# Altium schematic sheet [06] CONNECTIVITY.SchDoc("[06] CONNECTIVITY")
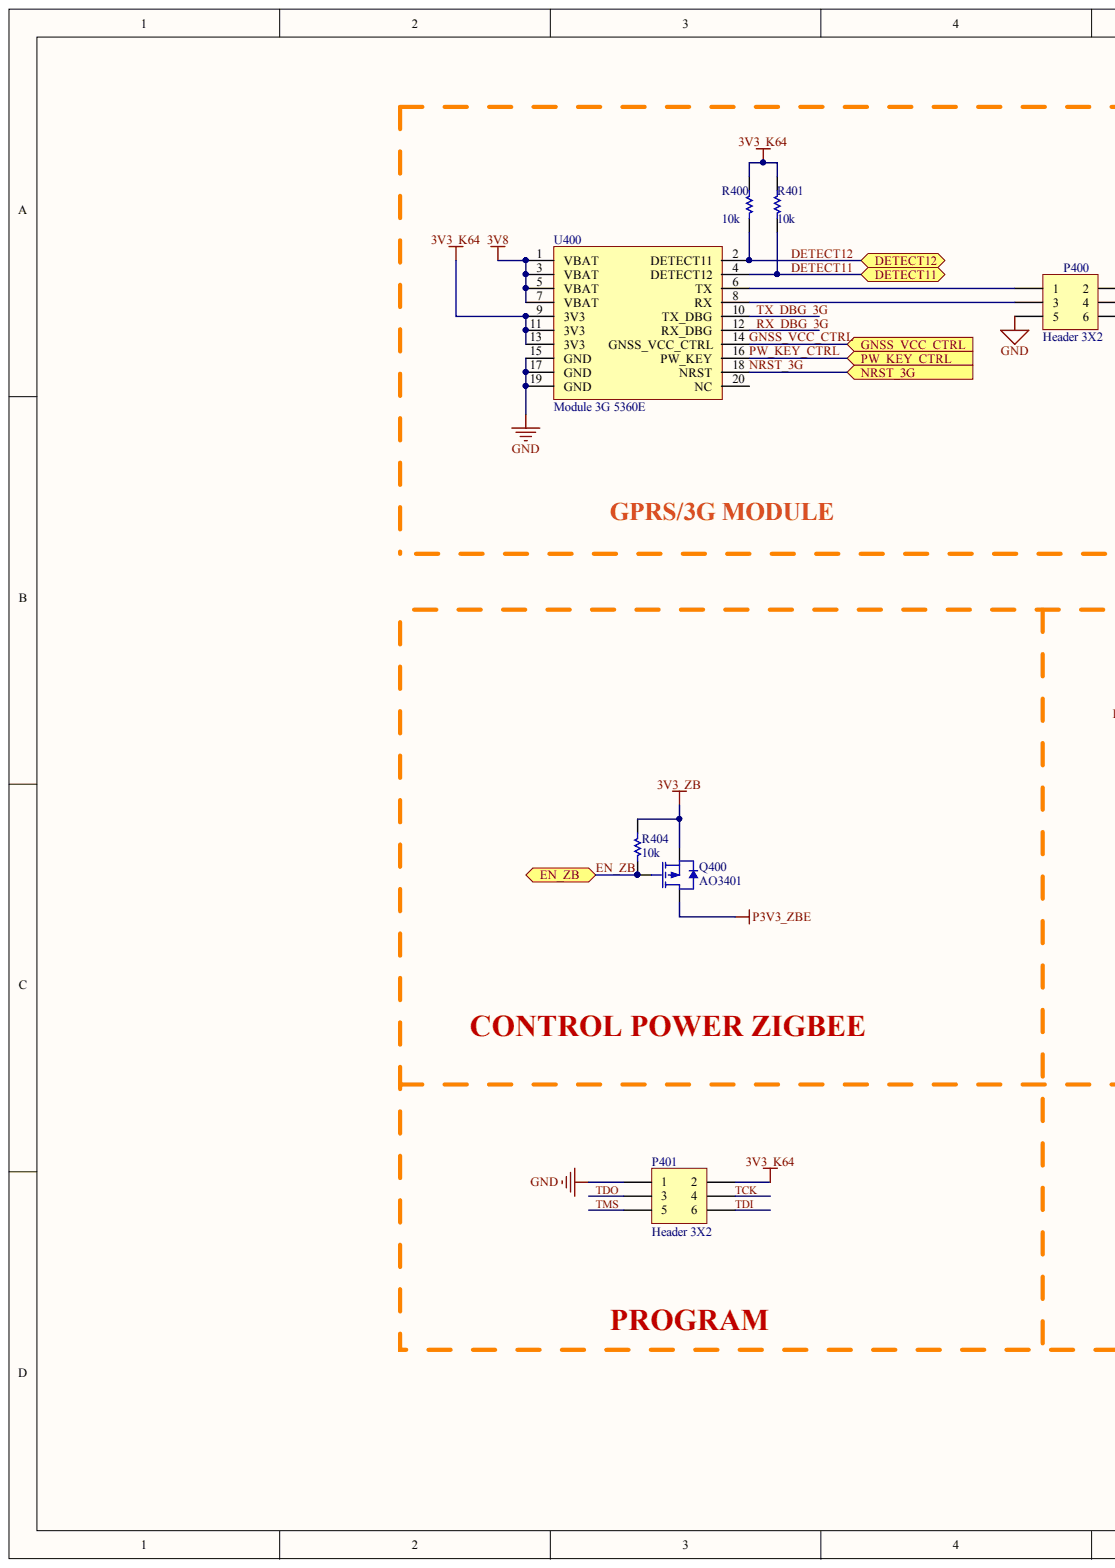
[diagram p0: [06] CONNECTIVITY.SchDoc, left]
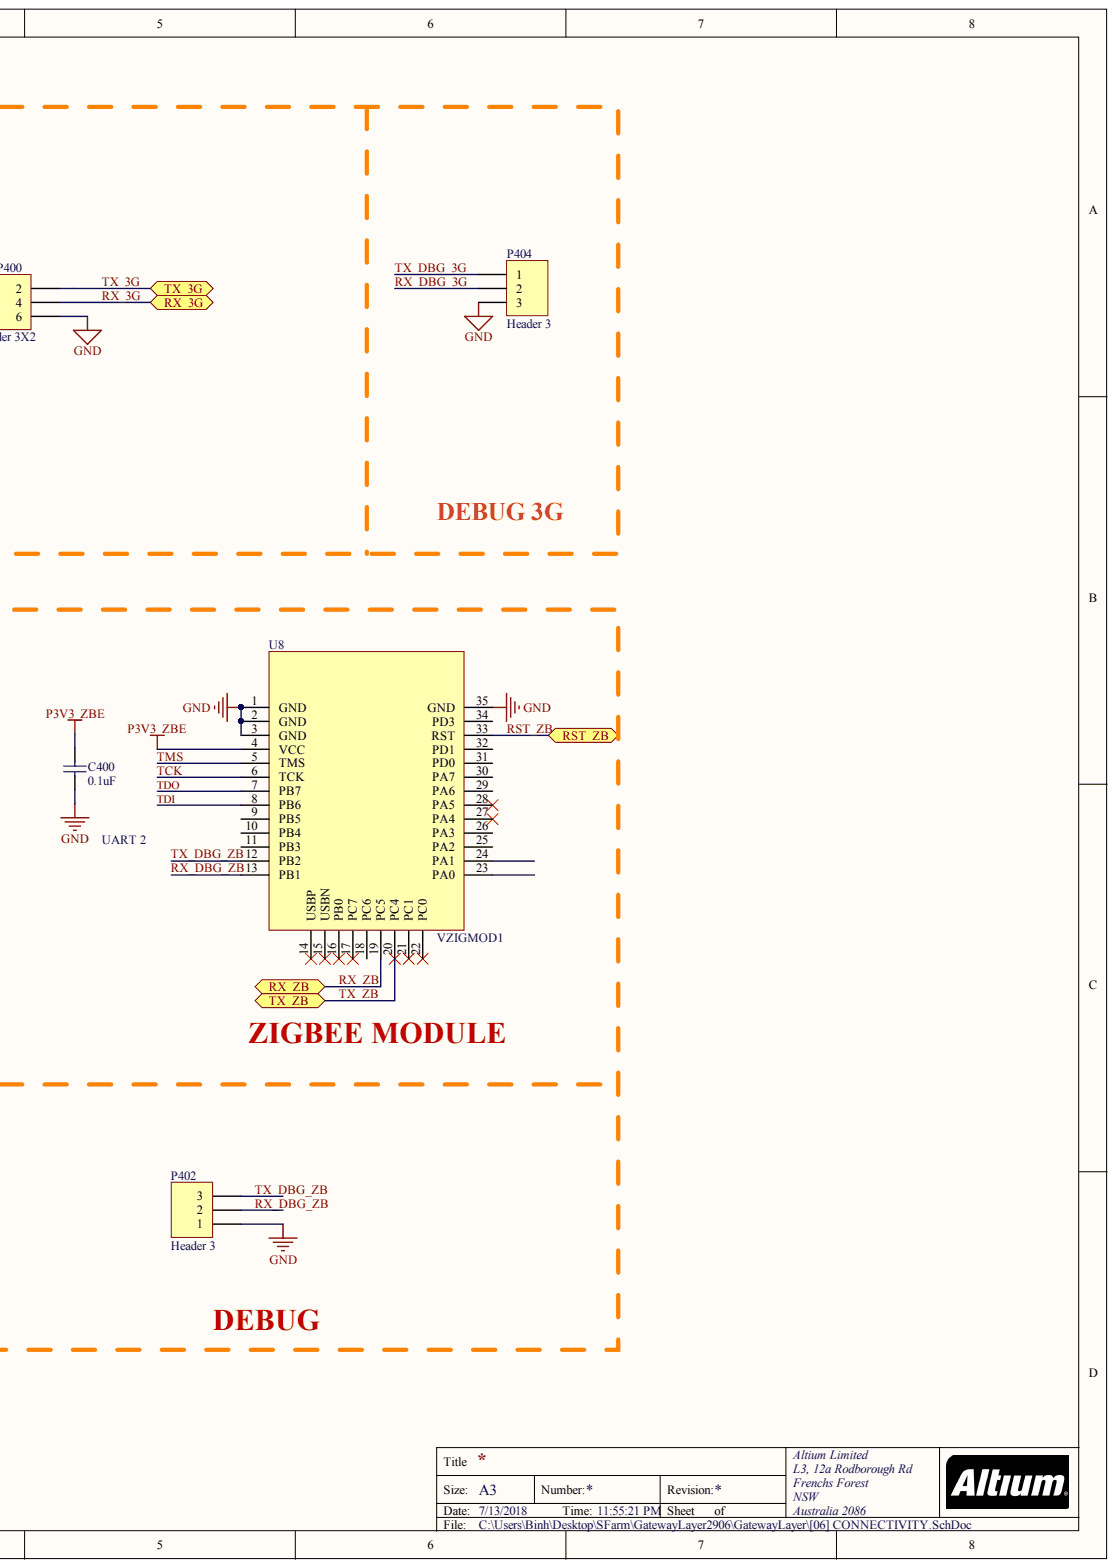
[diagram p1: [06] CONNECTIVITY.SchDoc, right]

## Parts
C400  0.1uF  [0402C]
P400  Header 3X2  [HDR2X3]
P401  Header 3X2  [HDR2X3]
P402  Header 3  [HDR1X3]
P404  Header 3  [HDR1X3]
Q400  AO3401  [SOT23-3N]
R400  10k  [0603R]
R401  10k  [0603R]
R404  10k  [0603R]
U8  VZIGMOD1  [VZIGMOD2]
U400  Module 3G 5360E  [Module 3G]

## Ports
DETECT11, DETECT12, EN_ZB, GNSS_VCC_CTRL, NRST_3G, PW_KEY_CTRL, RST_ZB, RX_3G, RX_ZB, TX_3G, TX_ZB

## Nets
3V3_K64: P401.2 R400.2 R401.2 U400.9(3V3) U400.11(3V3) U400.13(3V3)
3V3_ZB: Q400.2 R404.2
3V8: U400.1(VBAT) U400.3(VBAT) U400.5(VBAT) U400.7(VBAT)
GND: C400.1 P400.5 P400.6 P401.1 P402.1 P404.3 U8.1(GND) U8.2(GND) U8.3(GND) U8.35(GND) U400.15(GND) U400.17(GND) U400.19(GND)
P3V3_ZBE: C400.2 Q400.3 U8.4(VCC)
DETECT11: R401.1 U400.4(DETECT12) ; port DETECT11
DETECT12: R400.1 U400.2(DETECT11) ; port DETECT12
EN_ZB: Q400.1 R404.1 ; port EN_ZB
GNSS_VCC_CTRL: U400.14(GNSS_VCC_CTRL) ; port GNSS_VCC_CTRL
NRST_3G: U400.18(NRST) ; port NRST_3G
PW_KEY_CTRL: U400.16(PW_KEY) ; port PW_KEY_CTRL
RST_ZB: U8.33(RST) ; port RST_ZB
RX_3G: P400.4 ; port RX_3G
RX_DBG_3G: P404.2 U400.12(RX_DBG)
RX_DBG_ZB: P402.2 U8.13(PB1)
RX_ZB: U8.19(PC5) ; port RX_ZB
TCK: P401.4 U8.6(TCK)
TDI: P401.6 U8.8(PB6)
TDO: P401.3 U8.7(PB7)
TMS: P401.5 U8.5(TMS)
TX_3G: P400.2 ; port TX_3G
TX_DBG_3G: P404.1 U400.10(TX_DBG)
TX_DBG_ZB: P402.3 U8.12(PB2)
TX_ZB: U8.20(PC4) ; port TX_ZB
NetP400_1: P400.1 U400.6(TX)
NetP400_3: P400.3 U400.8(RX)

Not connected: U8 9(PB5) 10(PB4) 11(PB3) 14(USBP) 15(USBN) 16(PB0) 17(PC7) 18(PC6) 21(PC1) 22(PC0) 23(PA0) 24(PA1) 25(PA2) 26(PA3) 27(PA4) 28(PA5) 29(PA6) 30(PA7) 31(PD0) 32(PD1) 34(PD3); U400 20(NC)

## Library data of the parts
C400 = CAP0.1u0402: CAP CER 0.1UF 16V X7R 0402; Manufacturer: Samsung Electro-Mechanics; Manufacturer Part Number: CL05B104KO5NNNC; Module: Connectivity; Notification: Current market demand for these product types have resulted in fluctuating and extending lead times. Lead times may differ.; Tolerance: ±10%; Applications: General Purpose; Category: Capacitors; Component Kind: Standard; ComponentLink1Description: http://www.samsungsem.com/kr/support/product-search/mlcc/CL05B104KO5NNNC.jsp; ComponentLink1URL: http://www.samsungsem.com/kr/support/product-search/mlcc/CL05B104KO5NNNC.jsp; Features: -; Height - Seated (Max): -; Lead Spacing: -; Lead Style: -; Mounting Type: Surface Mount, MLCC; Operating Temperature: -55°C ~ 125°C; Package / Case: 0402 (1005 Metric); Packaging: Digi-Reel®; Part Status: Active; Pricing 1: 1=0.1, 10=0.03, 100=0.0134, 500=0.00958, 1000=0.00753, 2500=0.00684, 5000=0.0063 (USD); Ratings: -; RoHS: RoHS Compliant; Size / Dimension: 0.039" L x 0.020" W (1.00mm x 0.50mm); Stock 1: 100000; Supplier 1: Digi-Key; Supplier Part Number 1: 1276-1001-6-ND; Temperature Coefficient: X7R; Thickness (Max): 0.022" (0.55mm); Voltage - Rated: 16V; Library Name: BinhLib.IntLib
P400 P401 = Header 3X2: Header, 3-Pin, Dual row; Component Kind: Standard; LatestRevisionDate: 17-Jul-2002; LatestRevisionNote: Re-released for DXP Platform.; Signal Integrity: Connector; Library Name: BinhLib.IntLib; Publisher: Altium Limited
P402 P404 = Header 3: Header, 3-Pin; Component Kind: Standard; LatestRevisionDate: 17-Jul-2002; LatestRevisionNote: Re-released for DXP Platform.; Signal Integrity: Connector; Library Name: BinhLib.IntLib; Publisher: Altium Limited
Q400 = MOSFET-P1: P-Channel MOSFET; Component Kind: Standard; Library Name: BinhLib.IntLib
R400 R401 R404 = RES10k0603: RES SMD 10K OHM 1% 1/10W 0603; Manufacturer: Panasonic Electronic Components; Manufacturer Part Number: ERJ-3EKF1002V; Power (Watts): 0.1W, 1/10W; Temperature Coefficient: ±100ppm/°C; Category: Resistors; Component Kind: Standard; ComponentLink1Description: https://industrial.panasonic.com/cdbs/www-data/pdf/RDA0000/AOA0000C298.pdf; ComponentLink1URL: https://industrial.panasonic.com/cdbs/www-data/pdf/RDA0000/AOA0000C298.pdf; Composition: Thick Film; Failure Rate: -; Features: Automotive AEC-Q200; Height - Seated (Max): 0.022" (0.55mm); Operating Temperature: -55°C ~ 155°C; Package / Case: 0603 (1608 Metric); Packaging: Digi-Reel®; Part Status: Active; Pricing 1: 1=0.1, 10=0.021, 100=0.0087, 1000=0.00389, 2500=0.00338 (USD); Resistance (Ohms): 10k; RoHS: RoHS Compliant; Size / Dimension: 0.063" L x 0.031" W (1.60mm x 0.80mm); Stock 1: 0; Supplier 1: Digi-Key; Supplier Device Package: 0603; Supplier Part Number 1: P10.0KHDKR-ND; Tolerance: ±1%; Library Name: BinhLib.IntLib
U8 = VZIGMOD2: VNPT ZIGBEE MODULE V1 - No-PA; Manufacturer: VNPT-Technology; Utilized IC / Part: CC2538; Antenna Type: Integrated, PCB, External; Catgory: RF/IF and RFID; Data Rate: 250kbps; Frequency: 2.4GHz; Module: Connectivity; Power - Output: 7dBm; Sensitivity: -99dBm; Serial Interfaces: I²C, SPI, UART; Voltage - Supply: 1.85 V ~ 3.8 V; Component Kind: Standard; Library Name: SensorNode.SchLib; Manufacturer Part Number: VZIGMOD1; Mounting Type: Surface Mount; Operating Temperature: -40°C ~ 85°C; Package / Case: 33-SMD Module
U400 = Module 3G 5360E: Component Kind: Standard; Library Name: BinhLib.IntLib
